# Altium schematic sheet dv_analog_main.SchDoc(dv_analog_main)
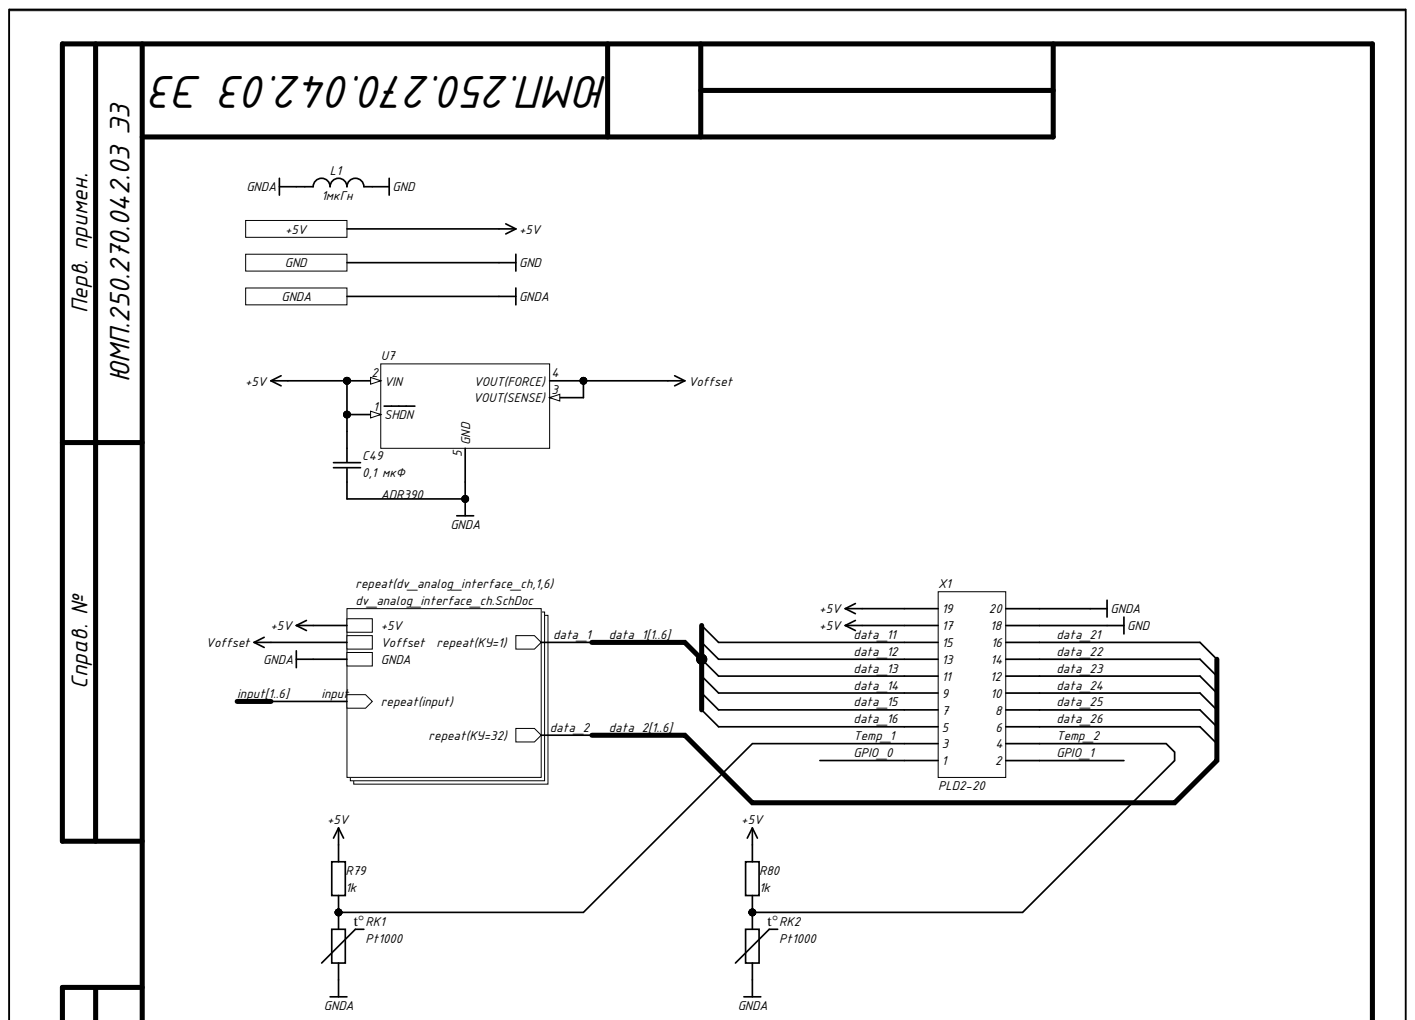
[diagram p0: dv_analog_main.SchDoc, top]
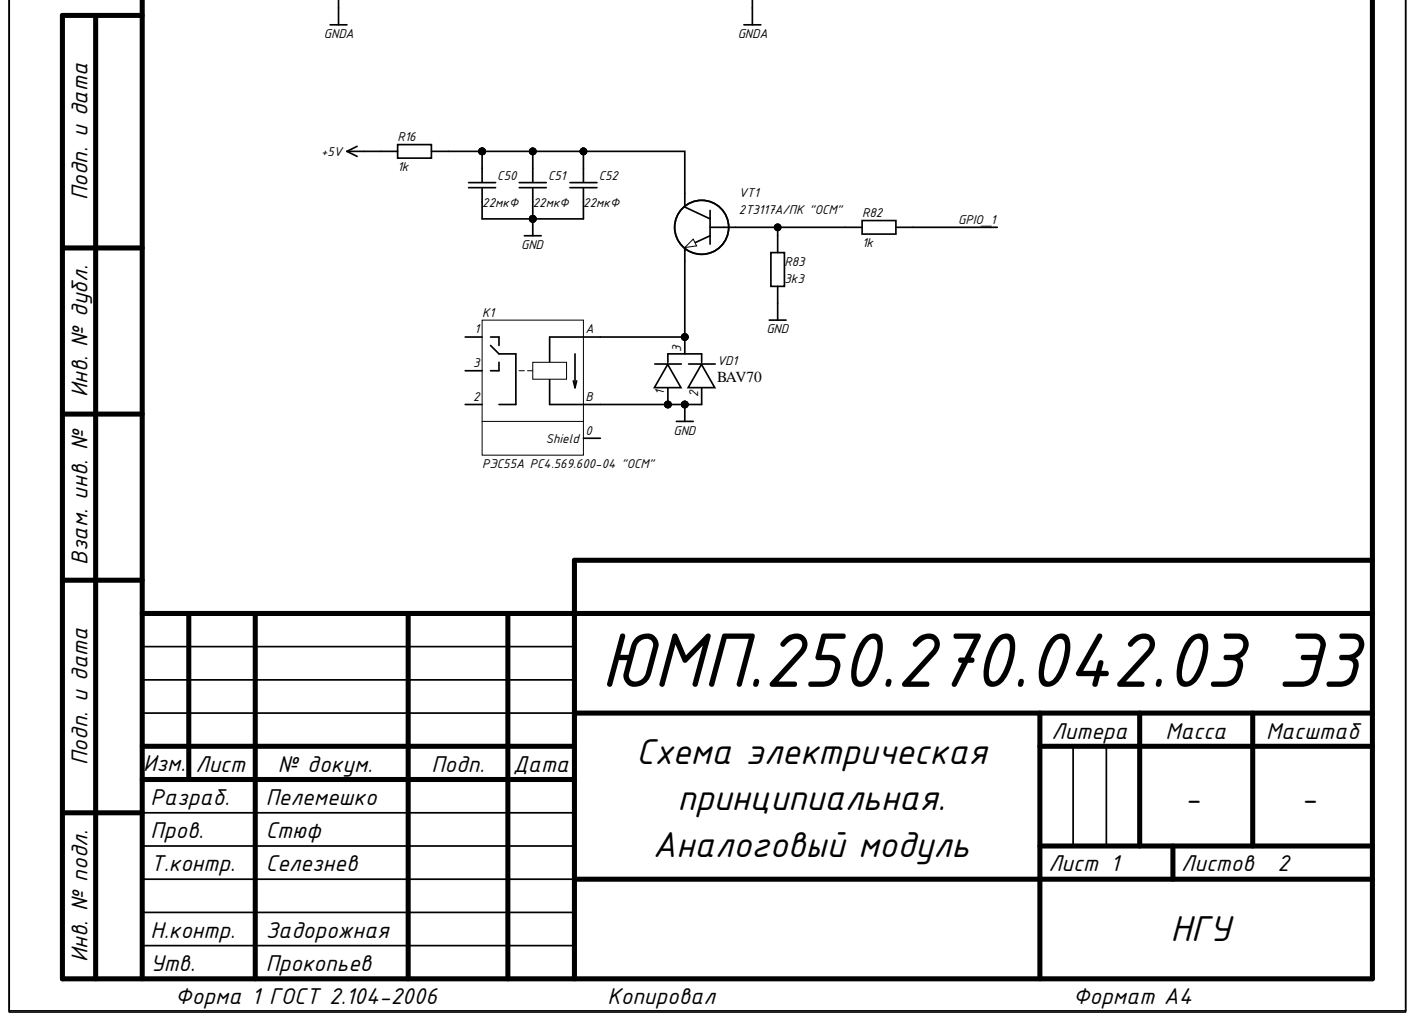
[diagram p1: dv_analog_main.SchDoc, bottom]

## Parts
C49  value 0,1 мкФ  [SMD_C0805]  (hidden comment: K10-79)
C50  value 22мкФ  [SMD_C1206]  (hidden comment: K10-79)
C51  value 22мкФ  [SMD_C1206]  (hidden comment: K10-79)
C52  value 22мкФ  [SMD_C1206]  (hidden comment: K10-79)
K1  Наименование: РЭС55А РС4.569.600-04 "ОСМ"  [RES55]  (hidden comment: RES55A)
L1  value 1мкГн  [SMD_0805]  (hidden comment: Inductor)
R16  value 1k  [SMD_0805]  (hidden comment: OSM R1-12)
R79  value 1k  [SMD_0805]  (hidden comment: OSM R1-12)
R80  value 1k  [SMD_0805]  (hidden comment: OSM R1-12)
R82  value 1k  [SMD_0805]  (hidden comment: OSM R1-12)
R83  value 3k3  [SMD_0805]  (hidden comment: OSM R1-12)
RK1  Pt1000  [Pt1000_3.0x1.0]
RK2  Pt1000  [Pt1000_3.0x1.0]
U7  Наименование: ADR390  [TSOT-5]  (hidden comment: ADR39х)
VD1  BAV70  [SOT-23]
VT1  2T3117A  [TO-18]  (value not drawn)
X1  Header 2X20  [PLD2-20]  (value not drawn)

## Ports
+5V, GND, GNDA

## Nets
Voffset: U7.3(VOUT(SENSE)) U7.4(VOUT(FORCE))
+5V: C49.2 R16.2 R79.2 R80.2 U7.1(SHDN) U7.2(VIN) X1.17 X1.19 ; port +5V
data_11: X1.15  [also labelled data_1]
data_12: X1.13  [also labelled data_1]
data_13: X1.11  [also labelled data_1]
data_14: X1.9  [also labelled data_1]
data_15: X1.7  [also labelled data_1]
data_16: X1.5  [also labelled data_1]
data_21: X1.16  [also labelled data_2]
data_22: X1.14  [also labelled data_2]
data_23: X1.12  [also labelled data_2]
data_24: X1.10  [also labelled data_2]
data_25: X1.8  [also labelled data_2]
data_26: X1.6  [also labelled data_2]
GND: C50.2 C51.2 C52.2 K1.B L1.2 R83.1 VD1.1 VD1.2 X1.18 ; port GND
GNDA: C49.1 L1.1 RK1.2 RK2.2 U7.5(GND) X1.20 ; port GNDA
GPIO_0: X1.1
GPIO_1: R82.2 X1.2
Temp_1: R79.1 RK1.1 X1.3
Temp_2: R80.1 RK2.1 X1.4
NetC50_1: C50.1 C51.1 C52.1 R16.1 VT1.3
NetK1_0: K1.0(Shield)
NetK1_1: K1.1
NetK1_2: K1.2
NetK1_3: K1.3
NetK1_A: K1.A VD1.3 VT1.1
NetR82_1: R82.1 R83.2 VT1.2
input1:  [also labelled input]
input2:  [also labelled input]
input3:  [also labelled input]
input4:  [also labelled input]
input5:  [also labelled input]
input6:  [also labelled input]

## Library data of the parts
C49 = Capacitor: Value: 0,1 мкФ; Назначение: SMD-конденсатор; Наименование: К10-79; Напряжение: В; Потребление, Вт: 0; Точность: %; Component Kind: Standard; Description: Capacitor; Library Name: Passive.IntLib
C50-C52 = Capacitor: Назначение: SMD-конденсатор; Наименование: К10-79; Напряжение: В; Потребление, Вт: 0; Точность: %; Component Kind: Standard; Description: Capacitor; Library Name: Passive.IntLib
K1 = RES55: Одностабильное неполяризованное герконовое реле; Потребление, Вт: 0,03; Component Kind: Standard; ComponentLink1Description: DataSheet; ComponentLink1URL: https://eandc.ru/pdf/rele/res55.pdf; Назначение: Одностабильное неполяризованное герконовое реле; Library Name: Commutator.IntLib
L1 = Inductor: Назначение: SMD-индуктивность; Наименование: Индуктивность; Потребление, Вт: 0,010; Component Kind: Standard; Description: Inductor; Library Name: Passive.IntLib
R16 R79 R80 R82 R83 = Resistor: Назначение: SMD-резистор; Наименование: ОСМ Р1-12; Потребление, Вт: 0,001; Точность: %; Component Kind: Standard; Description: Resistor; Library Name: Passive.IntLib
RK1 RK2 = Pt1000: Платиновый термометр сопротивления; Value: 1000; Потребление, Вт: 0,001; Component Kind: Standard; ComponentLink1Description: DataSheet; ComponentLink1URL: https://ru.mouser.com/datasheet/2/619/M310_HST-USA-1131966.pdf; Library Name: Sensor.IntLib; Назначение: Термометр сопротивления; Наименование: Pt1000
U7 = ADR39x: Micropower, Low Noise Precision Voltage Reference with Shutdown, 2.5 V Output, 2.8 to 15 V Supply, Industrial, 5-pin SOT23 (UJ-5), Reel; Manufacturer: Analog Devices; PartNumber: ADR391BUJZ-REEL7; Max V Supply (V): 15; Min V Supply (V): 2.8; Ref Out TC (ppm/C): 9; Vout: 2.5; Назначение: Источник опорного напряжения; Потребление, Вт: 0,001; Code_JEDEC: MO-193-AB; Component Kind: Standard; ComponentLink1Description: Manufacturer URL; ComponentLink1URL: http://www.analog.com/en/index.html; ComponentLink2Description: Datasheet; ComponentLink2URL: http://www.analog.com/static/imported-files/data_sheets/ADR391_392_395.pdf; ComponentLink3Description: Package Specification; ComponentLink3URL: http:/www.analog.com/static/imported-files/packages/PKG_PDF/TSOT(UJ)/UJ_5.pdf; ComponentLink4Description: URL Link1; ComponentLink4URL: Product URL:http://www.analog.com/en/special-linear-functions/voltage-references/adr391/products/product.html; DatasheetVersion: Rev. H; Library Name: ADR39x.Schlib; Mounting Technology: Surface Mount; Operating Temp Range: -40 to 125 degC; PackageDescription: 5-Pin Thin Small Outline Transistor Package, Body 2.9 x 1.6 mm, Pitch 0.95 mm; PackageReference: UJ-5; PackageVersion: 100708-A, 10/2008; Packing: Reel
VD1 = BAV70: Назначение: Диодная сборка; Component Kind: Standard; ComponentLink1Description: DataSheet; ComponentLink1URL: https://static.chipdip.ru/lib/635/DOC005635893.pdf; Наименование: BAV70; Library Name: Diod.IntLib
VT1 = 2T3117A: Кремниевый биполярный  эпитаксиально-планарный  n-p-n транзистор; Назначение: Биполярный транзистор; Наименование: 2Т3117А/ПК "ОСМ"; Потребление, Вт: 0,0; Component Kind: Standard; ComponentLink1Description: DataSheet; ComponentLink1URL: https://www.integral.by/sites/default/files/pdf/2t3117.pdf; Library Name: Transistor.IntLib
X1 = Header 2X20: Header, 20-Pin, Dual row; Потребление, Вт: 0,0; Component Kind: Standard; Library Name: Connector.IntLib; Назначение: Header, 20-Pin, Dual row; Наименование: PLD2-20
